# Altium schematic sheet Sheet_RS-485.SchDoc
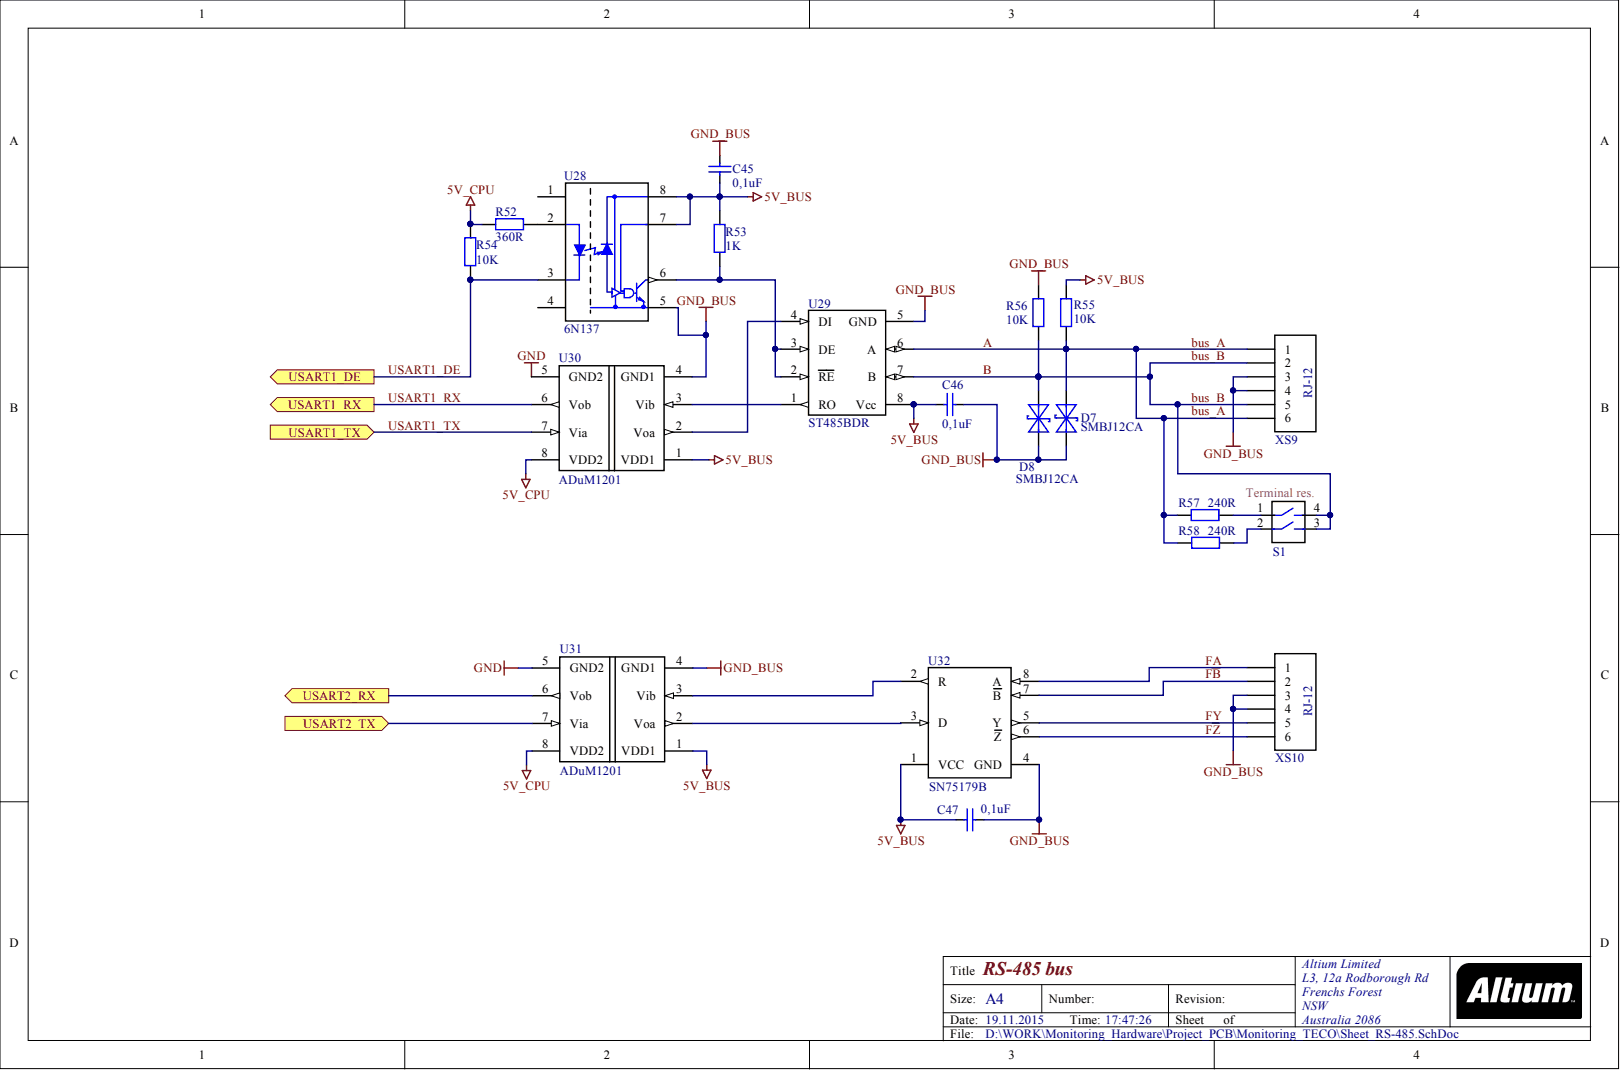
[diagram p0: Sheet_RS-485.SchDoc]

## Parts
C45  0,1uF  [C_0805]
C46  0,1uF  [C_0805]
C47  0,1uF  [C_0805]
D7  SMBJ12CA  [SMB]
D8  SMBJ12CA  [SMB]
R52  value 360R  [R_1206]  (hidden comment: Resistor 1206)
R53  value 1K  [R_1206]  (hidden comment: Resistor 1206)
R54  value 10K  [R_1206]  (hidden comment: Resistor 1206)
R55  value 10K  [R_1206]  (hidden comment: Resistor 1206)
R56  value 10K  [R_1206]  (hidden comment: Resistor 1206)
R57  value 240R  [R_1206]  (hidden comment: Resistor 1206)
R58  value 240R  [R_1206]  (hidden comment: Resistor 1206)
S1  SW DIP-2  [Swich_DIP2]  (value not drawn)
U28  6N137  [DIP-8]
U29  ST485BDR  [SO-8_N]
U30  ADuM1201  [SO-8_N]
U31  ADuM1201  [SO-8_N]
U32  SN75179B  [SO-8_L]
XS9  RJ-12  [RJ-12 - TJ4-6P6C]
XS10  RJ-12  [RJ-12 - TJ4-6P6C]

## Ports
USART1_DE, USART1_RX, USART1_TX, USART2_RX, USART2_TX

## Nets
5V_BUS: C45.1 C46.2 C47.2 R53.2 R55.2 U28.7 U28.8 U29.8(Vcc) U30.1(VDD1) U31.1(VDD1) U32.1(VCC)
5V_CPU: R52.1 R54.2 U30.8(VDD2) U31.8(VDD2)
GND: U30.5(GND2) U31.5(GND2)
GND_BUS: C45.2 C46.1 C47.1 D7.1 D8.2 R56.2 U28.5 U29.5(GND) U30.4(GND1) U31.4(GND1) U32.4(GND) XS9.3 XS9.4 XS10.3 XS10.4
A: D7.2 R55.1 R57.1 R58.1 U29.6(A) XS9.1 XS9.6
B: D8.1 R56.1 S1.3 S1.4 U29.7(B) XS9.2 XS9.5
FA: U32.8(A) XS10.1
F~{B}: U32.7(B) XS10.2
FY: U32.5(Y) XS10.5
F~{Z}: U32.6(Z) XS10.6
USART1_DE: R54.1 U28.3 ; port USART1_DE
USART1_RX: U30.6(Vob) ; port USART1_RX
USART1_TX: U30.7(Via) ; port USART1_TX
USART2_RX: U31.6(Vob) ; port USART2_RX
USART2_TX: U31.7(Via) ; port USART2_TX
NetR52_2: R52.2 U28.2
NetR53_1: R53.1 U28.6 U29.2(RE) U29.3(DE)
NetR57_2: R57.2 S1.1
NetR58_2: R58.2 S1.2
NetU29_1: U29.1(RO) U30.3(Vib)
NetU29_4: U29.4(DI) U30.2(Voa)
NetU31_2: U31.2(Voa) U32.3(D)
NetU31_3: U31.3(Vib) U32.2(R)

Not connected: U28 1 4

## Library data of the parts
C45-C47 = Cap_Semi: Capacitor semi; Component Kind: Standard; Library Name: Capacitor.SchLib
D7 D8 = SMBJ12CA: Supressor; Component Kind: Standard; Library Name: Diode.SchLib
S1 = SW DIP-2: DIP Switch_2; Component Kind: Standard; Library Name: Other.SchLib
U28 = 6N137: Optocoupler; Component Kind: Standard; Library Name: Chip_Packaging.SchLib
U29 = ST485BDR: RS-485/RS-422 Transceiver; Component Kind: Standard; Library Name: Chip_Packaging.SchLib
U30 U31 = ADuM1201: Dual-Channel Digital Isolators; Component Kind: Standard; Library Name: Chip_Packaging.SchLib
U32 = SN75179B: RS485/RS422 Differential driver and receiver pair; Component Kind: Standard; Library Name: Chip_Packaging.SchLib
XS9 XS10 = RJ-12: Connector RJ-12; Component Kind: Standard; Library Name: Connect.SchLib
R52-R58 = Resistor 1206: Component Kind: Standard; Description: Resistor 1206; Library Name: Resistors.SchLib
